# Altium schematic sheet Heater Heat control.SchDoc
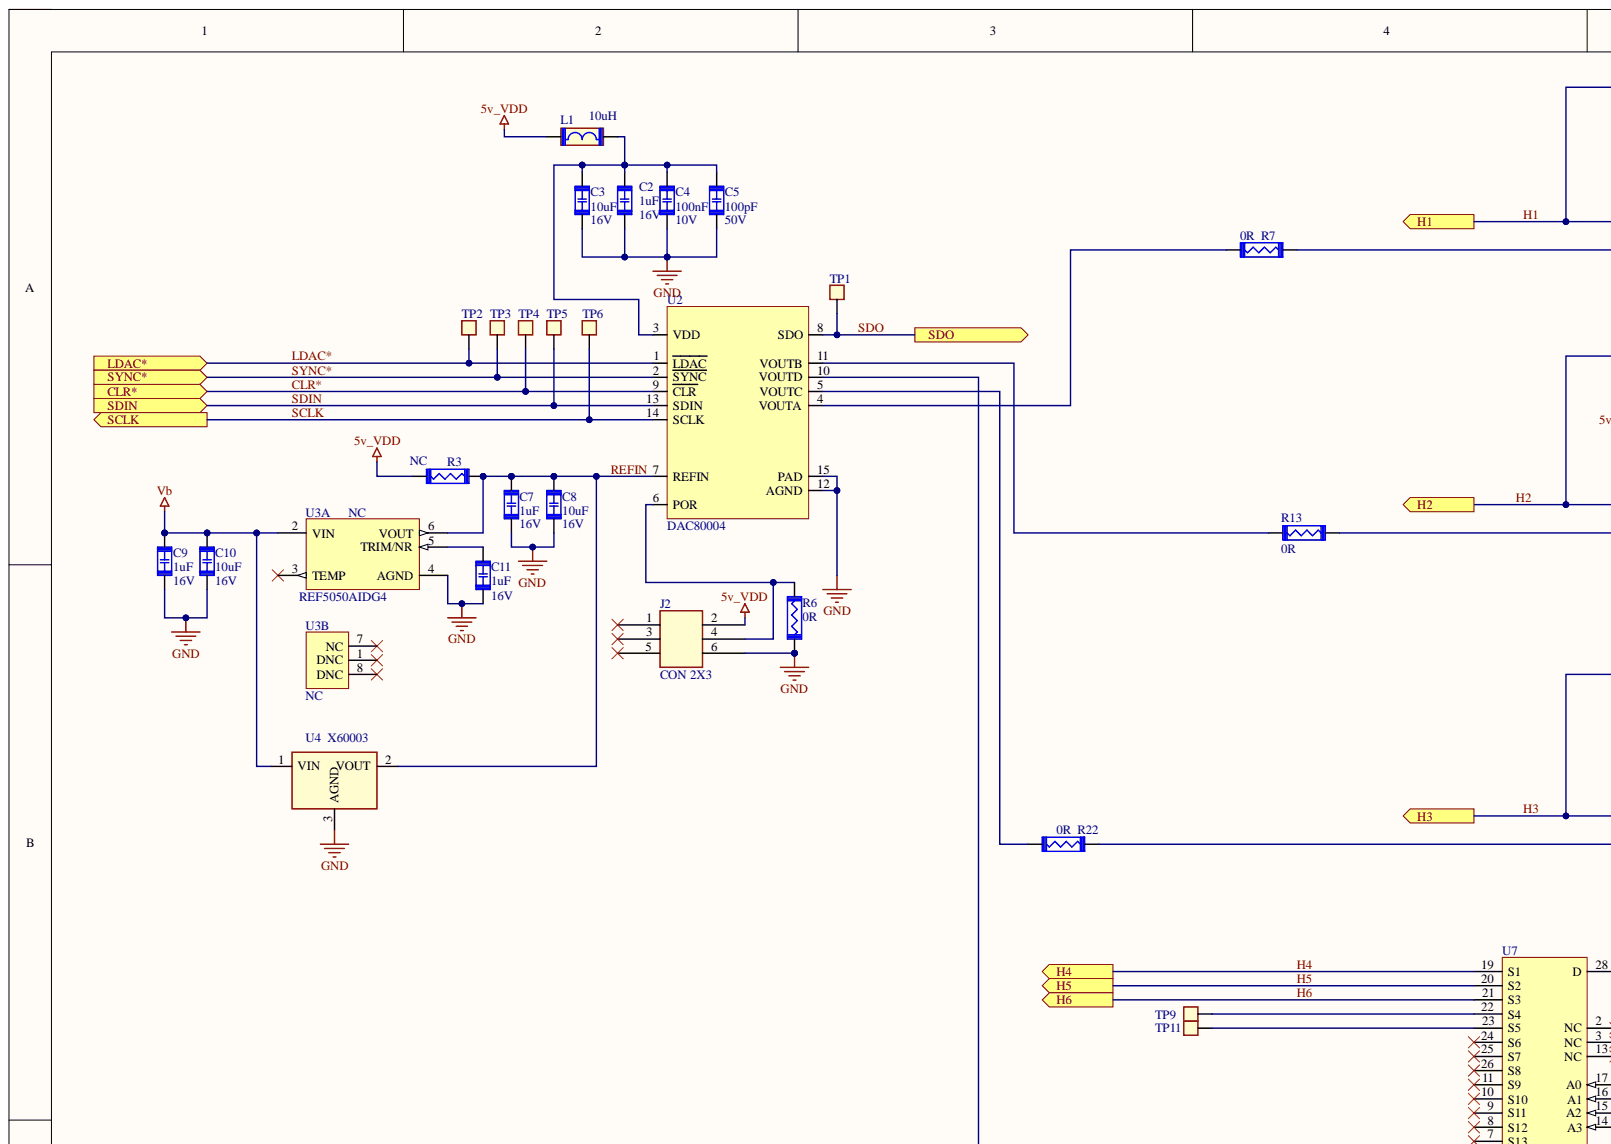
[diagram p0: Heater Heat control.SchDoc, top left]
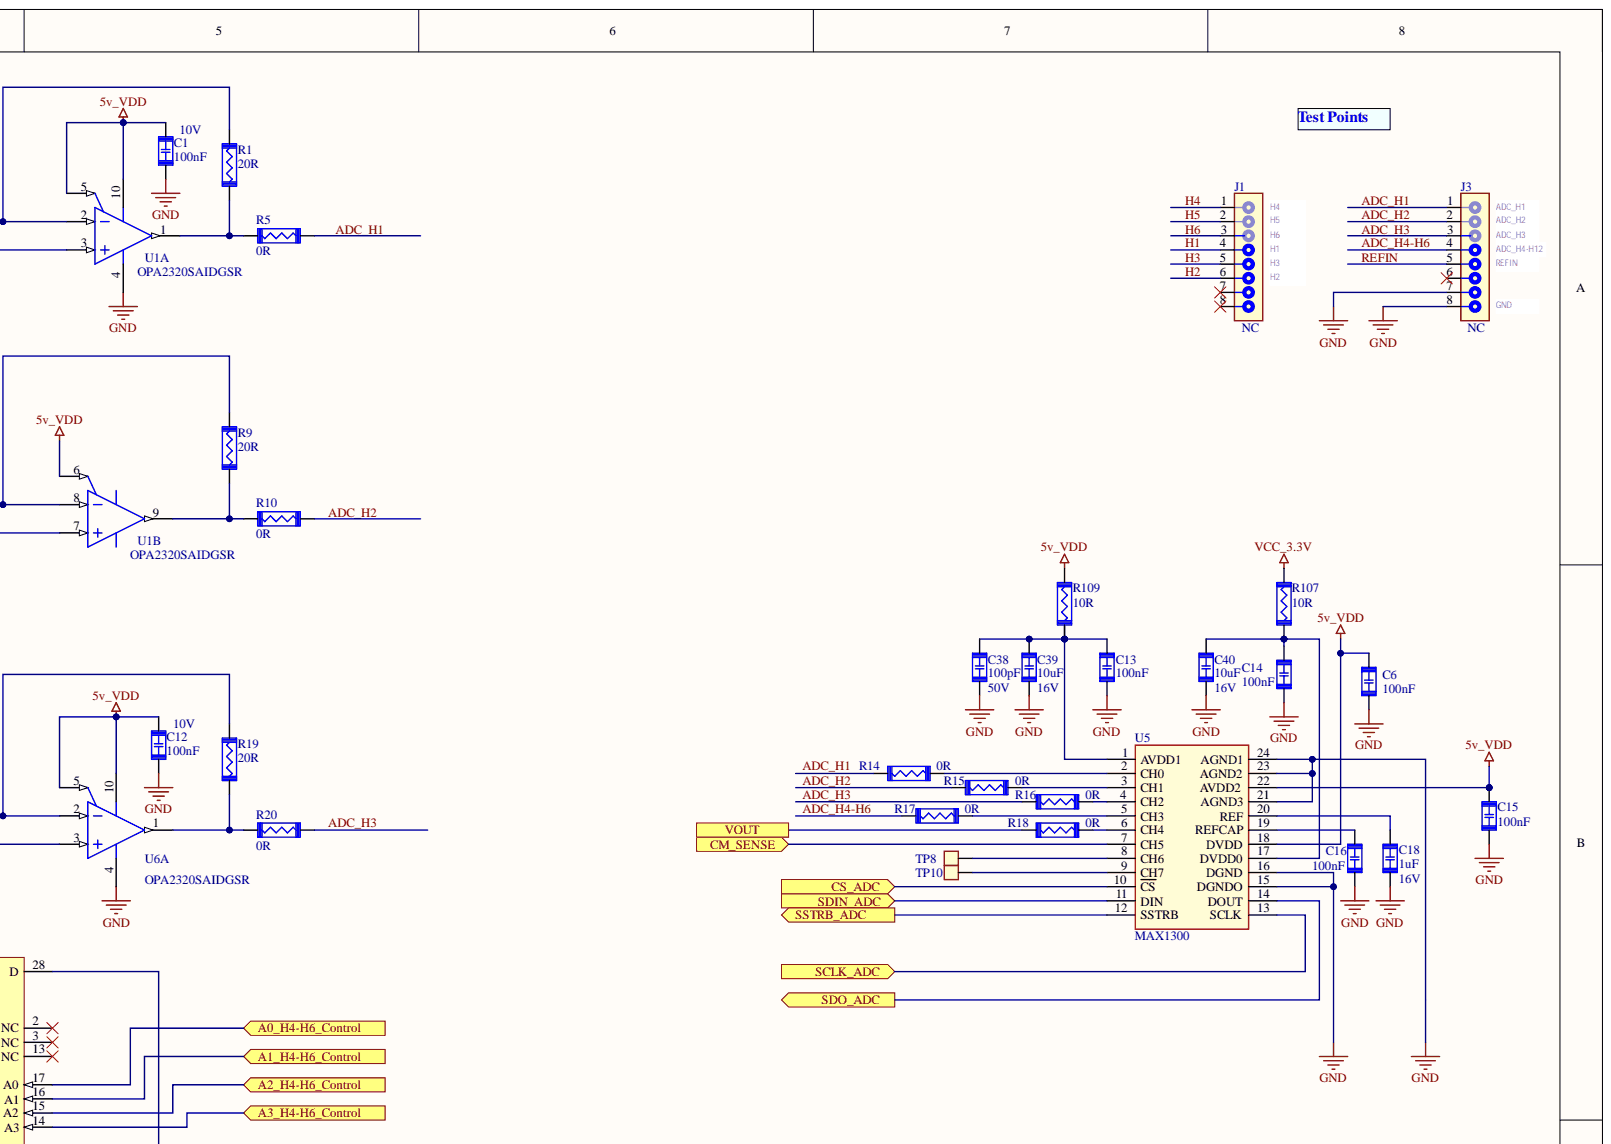
[diagram p1: Heater Heat control.SchDoc, top right]
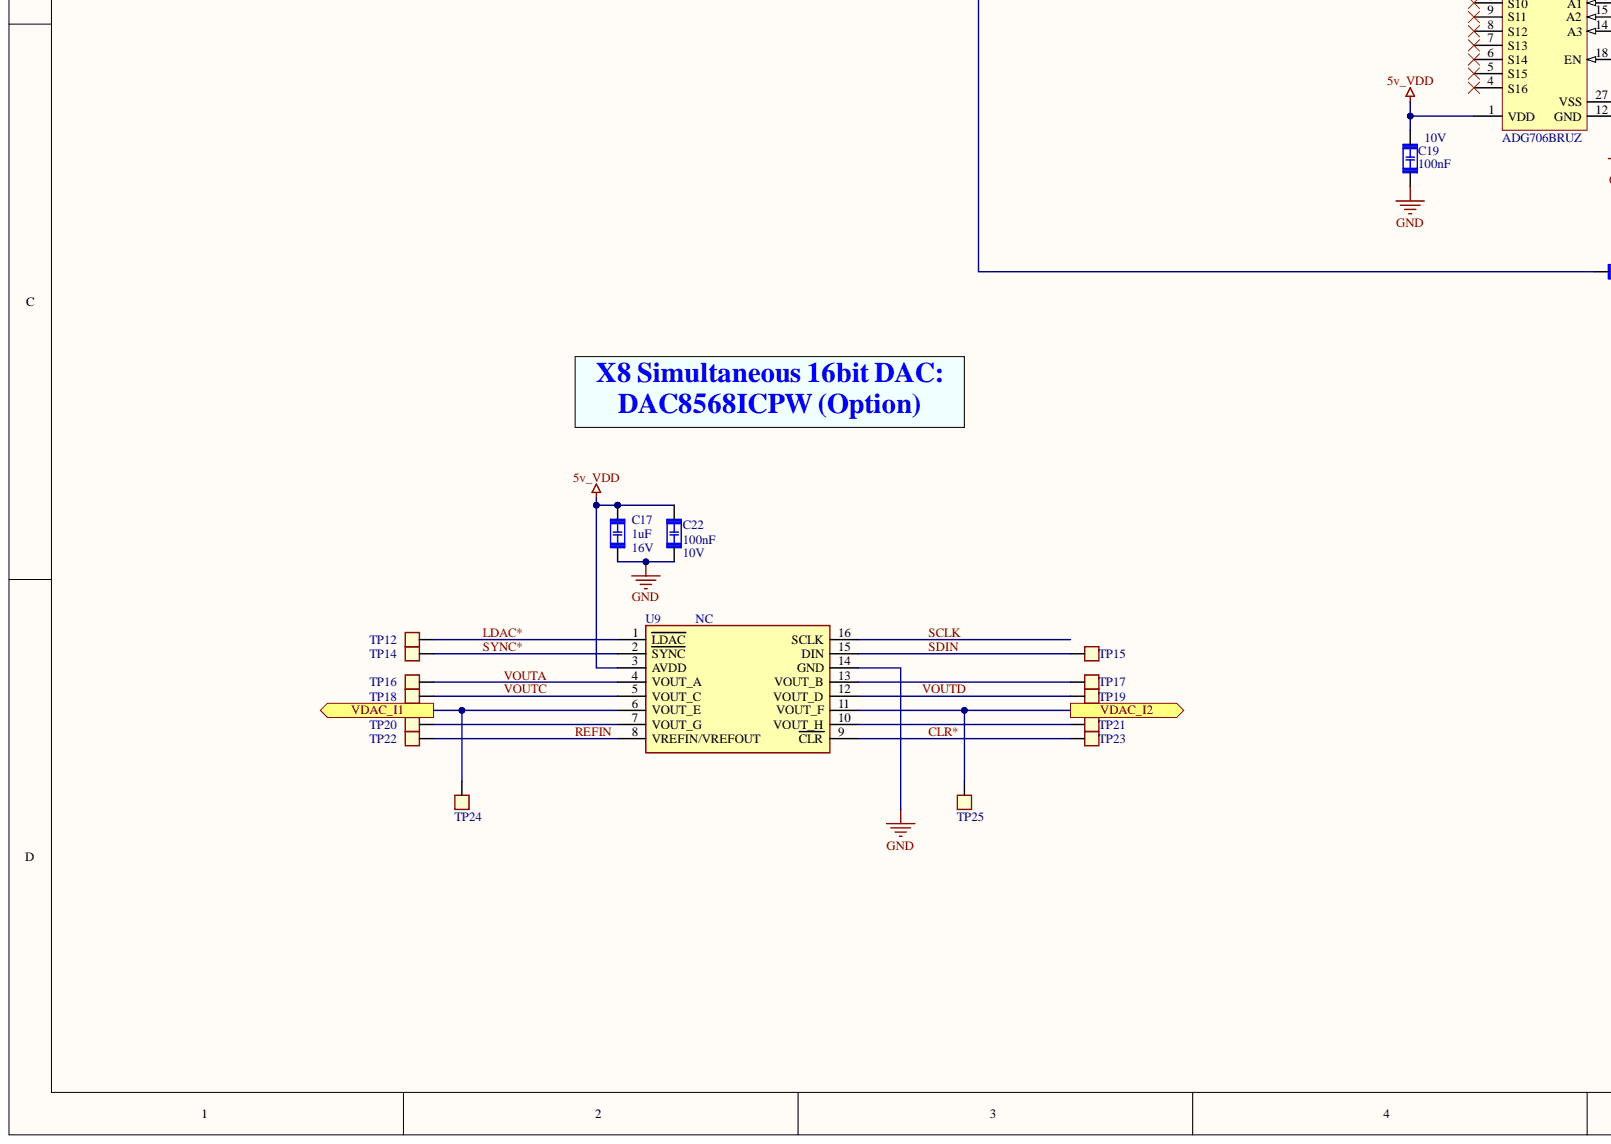
[diagram p2: Heater Heat control.SchDoc, bottom left]
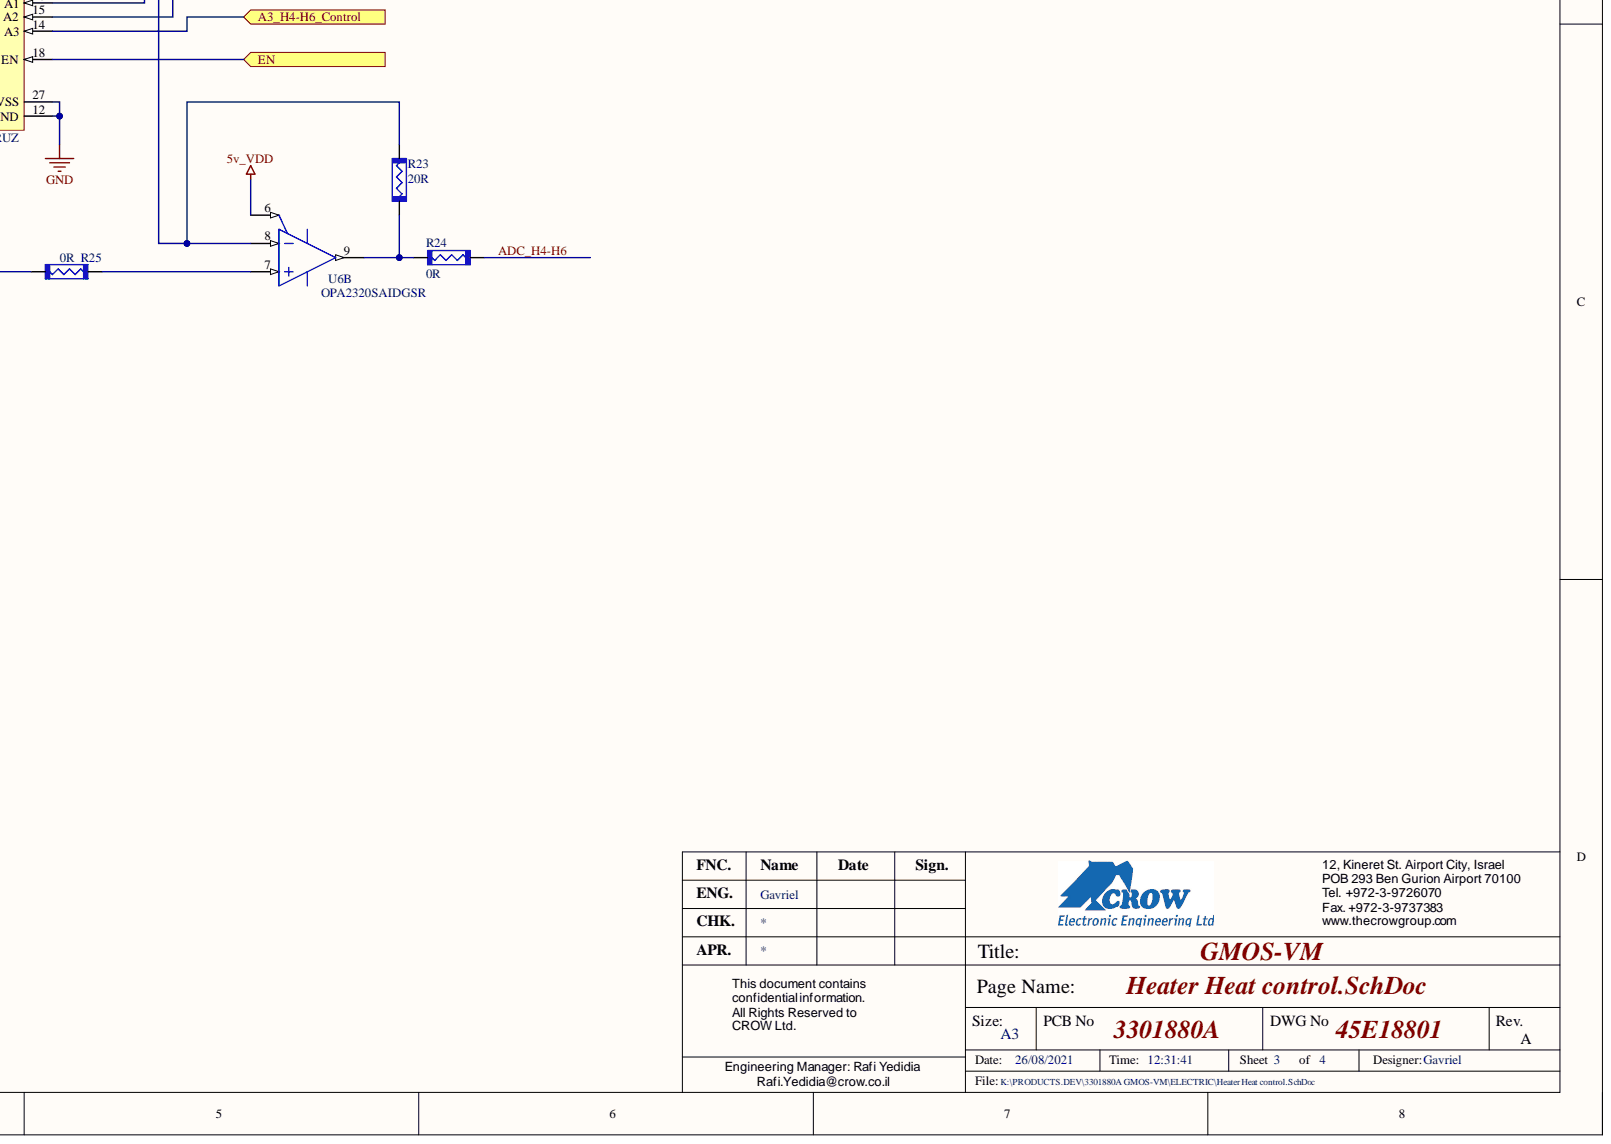
[diagram p3: Heater Heat control.SchDoc, bottom right]

## Parts
C1  100nF  Voltage: 10V  [C0402/C]
C2  1uF  Voltage: 16V  [C0402/C]
C3  10uF  Voltage: 16V  [C0603/C]
C4  100nF  Voltage: 10V  [C0402/C]
C5  100pF  Voltage: 50V  [C0402/C]
C6  100nF  [C0402/C]
C7  1uF  Voltage: 16V  [C0402/C]
C8  10uF  Voltage: 16V  [C0603/C]
C9  1uF  Voltage: 16V  [C0402/C]
C10  10uF  Voltage: 16V  [C0603/C]
C11  1uF  Voltage: 16V  [C0402/C]
C12  100nF  Voltage: 10V  [C0402/C]
C13  100nF  [C0402/C]
C14  100nF  [C0402/C]
C15  100nF  [C0402/C]
C16  100nF  [C0402/C]
C17  1uF  Voltage: 16V  [C0402/C]
C18  1uF  Voltage: 16V  [C0402/C]
C19  100nF  Voltage: 10V  [C0402/C]
C22  100nF  Voltage: 10V  [C0402/C]
C38  100pF  Voltage: 50V  [C0402/C]
C39  10uF  Voltage: 16V  [C0603/C]
C40  10uF  Voltage: 16V  [C0603/C]
J1  NC  [SIP100_8P_3B_H36.5]
J2  CON 2X3  [PH2X3SMT2.54_H2.5]
J3  NC  [SIP100_8P_3B_H36.5]
L1  10uH  [L_epl2010]
R1  20R  [R0402/C]
R3  NC  [R0402/C]
R5  0R  [R0402/C]
R6  0R  [R0402/C]
R7  0R  [R0402/C]
R9  value 20R  [R0402/C]  (hidden comment: Value)
R10  value 0R  [R0402/C]  (hidden comment: Value)
R13  value 0R  [R0402/C]  (hidden comment: Value)
R14  0R  [R0402/C]
R15  0R  [R0402/C]
R16  0R  [R0402/C]
R17  0R  [R0402/C]
R18  0R  [R0402/C]
R19  20R  [R0402/C]
R20  0R  [R0402/C]
R22  0R  [R0402/C]
R23  20R  [R0402/C]
R24  0R  [R0402/C]
R25  0R  [R0402/C]
R107  10R  [R0805/C]
R109  10R  [R0805/C]
TP1  TP-1.5MM  [TP-1.5mm]  (value not drawn)  (no BOM)
TP2  TP-1.5MM  [TP-1.5mm]  (value not drawn)  (no BOM)
TP3  TP-1.5MM  [TP-1.5mm]  (value not drawn)  (no BOM)
TP4  TP-1.5MM  [TP-1.5mm]  (value not drawn)  (no BOM)
TP5  TP-1.5MM  [TP-1.5mm]  (value not drawn)  (no BOM)
TP6  TP-1.5MM  [TP-1.5mm]  (value not drawn)  (no BOM)
TP8  TP-1MM_1  [TP-1.5MM]  (value not drawn)  (no BOM)
TP9  TP-1MM_1  [TP-1.5MM]  (value not drawn)  (no BOM)
TP10  TP-1MM_1  [TP-1.5MM]  (value not drawn)  (no BOM)
TP11  TP-1MM_1  [TP-1.5MM]  (value not drawn)  (no BOM)
TP12  TP-1MM_1  [TP-1.5MM]  (value not drawn)  (no BOM)
TP14  TP-1MM_1  [TP-1.5MM]  (value not drawn)  (no BOM)
TP15  TP-1MM_1  [TP-1.5MM]  (value not drawn)  (no BOM)
TP16  TP-1MM_1  [TP-1.5MM]  (value not drawn)  (no BOM)
TP17  TP-1MM_1  [TP-1.5MM]  (value not drawn)  (no BOM)
TP18  TP-1MM_1  [TP-1.5MM]  (value not drawn)  (no BOM)
TP19  TP-1MM_1  [TP-1.5MM]  (value not drawn)  (no BOM)
TP20  TP-1MM_1  [TP-1.5MM]  (value not drawn)  (no BOM)
TP21  TP-1MM_1  [TP-1.5MM]  (value not drawn)  (no BOM)
TP22  TP-1MM_1  [TP-1.5MM]  (value not drawn)  (no BOM)
TP23  TP-1MM_1  [TP-1.5MM]  (value not drawn)  (no BOM)
TP24  TP-1MM_1  [TP-1.5MM]  (value not drawn)  (no BOM)
TP25  TP-1MM_1  [TP-1.5MM]  (value not drawn)  (no BOM)
U1  OPA2320SAIDGSR  [SOPM_10/C]  (parts A,B)
U2  DAC80004  [VSON14_3x4x1_050]
U3  NC  value REF5050AIDG4  [SOIC8_W6XL5XH1.75]  (parts A,B)
U4  X60003  [SOT23/C]
U5  MAX1300  [TSSOP24_7.8x4.4X1.1_065]
U6  OPA2320SAIDGSR  [SOPM_10/C]  (parts A,B)
U7  ADG706BRUZ  [ADI-RU-28_L]
U9  NC  [TSSOP16_4.4X5.0_0.65]

## Ports
A0_H4-H6_Control, A1_H4-H6_Control, A2_H4-H6_Control, A3_H4-H6_Control, CLR*, CM_SENSE, CS_ADC, EN, H1, H2, H3, H4, H5, H6, LDAC*, SCLK, SCLK_ADC, SDIN, SDIN_ADC, SDO, SDO_ADC, SSTRB_ADC, SYNC*, VDAC_I1, VDAC_I2, VOUT

## Nets
5v_VDD: C1.1 C6.1 C12.1 C15.1 C17.1 C19.1 C22.1 J2.2 L1.1 R3.2 R109.2 U1.5 U1.6 U1.10 U5.18(DVDD) U5.22(AVDD2) U6.5 U6.6 U6.10 U7.1(VDD) U9.3(AVDD)
GND: C1.2 C2.2 C3.2 C4.2 C5.2 C6.2 C7.2 C8.2 C9.2 C10.2 C11.2 C12.2 C13.2 C14.2 C15.2 C16.2 C17.2 C18.2 C19.2 C22.2 C38.1 C39.2 C40.2 J2.6 J3.7 J3.8 R6.2 U1.4 U2.12(AGND) U2.15(PAD) U3.4(AGND) U4.3 U5.15(DGNDO) U5.16(DGND) U5.21(AGND3) U5.23(AGND2) U5.24(AGND1) U6.4 U7.12(GND) U7.27(VSS) U9.14(GND)
Vb: C9.1 C10.1 U3.2(VIN) U4.1
VCC_3.3V: R107.2
A0_H4-H6_Control: U7.17(A0) ; port A0_H4-H6_Control
A1_H4-H6_Control: U7.16(A1) ; port A1_H4-H6_Control
A2_H4-H6_Control: U7.15(A2) ; port A2_H4-H6_Control
A3_H4-H6_Control: U7.14(A3) ; port A3_H4-H6_Control
ADC_H1: J3.1 R5.1 R14.2
ADC_H2: J3.2 R10.1 R15.2
ADC_H3: J3.3 R16.2 R20.1
ADC_H4-H6: J3.4 R17.2 R24.1
CLR*: TP4.1 TP23.1 U2.9(CLR) U9.9(CLR) ; port CLR*
CM_SENSE: U5.7(CH5) ; port CM_SENSE
CS_ADC: U5.10(CS) ; port CS_ADC
EN: U7.18(EN) ; port EN
H1: J1.4 R1.1 U1.2 ; port H1
H2: J1.6 R9.1 U1.8 ; port H2
H3: J1.5 R19.1 U6.2 ; port H3
H4: J1.1 U7.19(S1) ; port H4
H5: J1.2 U7.20(S2) ; port H5
H6: J1.3 U7.21(S3) ; port H6
LDAC*: TP2.1 TP12.1 U2.1(LDAC) U9.1(LDAC) ; port LDAC*
REFIN: C7.1 C8.1 J3.5 R3.1 TP22.1 U2.7(REFIN) U3.6(VOUT) U4.2 U9.8(VREFIN/VREFOUT)
SCLK: TP6.1 U2.14(SCLK) U9.16(SCLK) ; port SCLK
SCLK_ADC: U5.13(SCLK) ; port SCLK_ADC
SDIN: TP5.1 TP15.1 U2.13(SDIN) U9.15(DIN) ; port SDIN
SDIN_ADC: U5.11(DIN) ; port SDIN_ADC
SDO: TP1.1 U2.8(SDO) ; port SDO
SDO_ADC: U5.14(DOUT) ; port SDO_ADC
SSTRB_ADC: U5.12(SSTRB) ; port SSTRB_ADC
SYNC*: TP3.1 TP14.1 U2.2(SYNC) U9.2(SYNC) ; port SYNC*
VDAC_I1: TP24.1 U9.6(VOUT_E) ; port VDAC_I1
VDAC_I2: TP25.1 U9.11(VOUT_F) ; port VDAC_I2
VOUT: R18.2 ; port VOUT
VOUTA: TP16.1 U9.4(VOUT_A)
VOUTC: TP18.1 U9.5(VOUT_C)
VOUTD: TP19.1 U9.12(VOUT_D)
NetC2_1: C2.1 C3.1 C4.1 C5.1 L1.2 U2.3(VDD)
NetC11_1: C11.1 U3.5(TRIM/NR)
NetC13_1: C13.1 C38.2 C39.1 R109.1 U5.1(AVDD1)
NetC14_1: C14.1 C40.1 R107.1 U5.17(DVDD0)
NetC16_1: C16.1 U5.19(REFCAP)
NetC18_1: C18.1 U5.20(REF)
NetJ2_4: J2.4 R6.1 U2.6(POR)
NetR1_2: R1.2 R5.2 U1.1
NetR7_1: R7.1 U1.3
NetR7_2: R7.2 U2.4(VOUTA)
NetR9_2: R9.2 R10.2 U1.9
NetR13_1: R13.1 U1.7
NetR13_2: R13.2 U2.11(VOUTB)
NetR14_1: R14.1 U5.2(CH0)
NetR15_1: R15.1 U5.3(CH1)
NetR16_1: R16.1 U5.4(CH2)
NetR17_1: R17.1 U5.5(CH3)
NetR18_1: R18.1 U5.6(CH4)
NetR19_2: R19.2 R20.2 U6.1
NetR22_1: R22.1 U6.3
NetR22_2: R22.2 U2.5(VOUTC)
NetR23_1: R23.1 U6.8 U7.28(D)
NetR23_2: R23.2 R24.2 U6.9
NetR25_1: R25.1 U6.7
NetR25_2: R25.2 U2.10(VOUTD)
NetTP8_1: TP8.1 U5.8(CH6)
NetTP9_1: TP9.1 U7.22(S4)
NetTP10_1: TP10.1 U5.9(CH7)
NetTP11_1: TP11.1 U7.23(S5)
NetTP17_1: TP17.1 U9.13(VOUT_B)
NetTP20_1: TP20.1 U9.7(VOUT_G)
NetTP21_1: TP21.1 U9.10(VOUT_H)

Not connected: J1 7 8; J2 1 3 5; J3 6; U3 1(DNC) 3(TEMP) 7 8(DNC); U7 2(NC) 3(NC) 4(S16) 5(S15) 6(S14) 7(S13) 8(S12) 9(S11) 10(S10) 11(S9) 13(NC) 24(S6) 25(S7) 26(S8)

## Library data of the parts
C1 C4 C6 C12-C16 C19 C22 = 1235104: CAP.SMD 100nF 10V X7R 0402 10%; Name Manufacturer#1: EYANG1; Name Manufacturer#2: KEMET; PN Manufacturer#1: 0402X104K100CT; PN Manufacturer#2: C0402C104K8RACT; Database Table Name: ALTM_Capacitors; Component Kind: Standard; ComponentLink1Description: Datasheet; Cost: 0.0005; Crow Part Number: 1235104; Package: SMD; Signal Integrity: Model Name; Simulation: Model Name; Tolerance: 10%; Value: 100nF; ComponentLink1URL: G:\SPEC\Capacitor\Ceramics\CAP SMD WALSIN.pdf; HelpURL: G:\SPEC\Capacitor\Ceramics\CAP SMD WALSIN.pdf; Library Name: Database_Crow.DbLib
  C1 C12 C19: Stock: 3379.81
  C4 C22: Stock: 3236.71
  C6 C13-C16: Stock: 3379.81; Voltage: 10V
C2 C7 C9 C11 C17 C18 = 1234105: CAP. SMD 1uF/16V X7R/X5R 0402 10%; Name Manufacturer#1: TDK; Name Manufacturer#2: TDK1; PN Manufacturer#1: C1005X5R1C105K0; PN Manufacturer#2: C1005X5R1C105KT; Database Table Name: ALTM_Capacitors; Component Kind: Standard; ComponentLink1Description: Datasheet; Cost: 0.0032; Crow Part Number: 1234105; Package: SMD; Signal Integrity: Model Name; Simulation: Model Name; Tolerance: 10%; Value: 1uF; Library Name: Database_Crow.DbLib
  C2 C7 C9 C11 C17: Stock: 1709.96
  C18: Stock: 1592.84
C3 C8 C10 C39 C40 = 1236106: CAP. SMD 10uF  0603 16V X5R  20%; Name Manufacturer#1: TDK; PN Manufacturer#1: PC1608X5R1C106M; Database Table Name: ALTM_Capacitors; Component Kind: Standard; ComponentLink1Description: Datasheet; Cost: 0.0306; Crow Part Number: 1236106; Package: SMD; Signal Integrity: Model Name; Simulation: Model Name; Stock: 33.18; Tolerance: 20%; Value: 10uF; Library Name: Database_Crow.DbLib
C5 C38 = 1230101: CAP. SMD 100PF COG 0402; Name Manufacturer#1: SAMSUNG; Name Manufacturer#2: SAMSUNG1; PN Manufacturer#1: CL05C101JB5NNNC; PN Manufacturer#2: CL05C101JB5NNND; Database Table Name: ALTM_Capacitors; Tolerance: 5%; Component Kind: Standard; ComponentLink1Description: Datasheet; Cost: 0.0006; Crow Part Number: 1230101; Package: SMD; Signal Integrity: Model Name; Simulation: Model Name; Stock: 1207.14; Value: 100pF; ComponentLink1URL: G:\SPEC\Capacitor\Ceramics\CAP SMD SAMSUNG.pdf; HelpURL: G:\SPEC\Capacitor\Ceramics\CAP SMD SAMSUNG.pdf; Library Name: Database_Crow.DbLib
J1 J3 = CON HEAD 8P: Component Kind: Standard; Library Name: Sch_Crow.SchLib
J2 = 1612203: CONNECTOR SMD DUAL ROW 6 Pin HEADER 2X3 2.54mm; Name Manufacturer#1: AMTEK; PN Manufacturer#1: PH1M25-203GBCPR; Database Table Name: ALTM_Connectors; Component Kind: Standard; ComponentLink1Description: Datasheet; ComponentLink1URL: G:/SPEC/Connectors/AMTEK/5PH1XXAXX-X-3.pdf; Cost: 0.0843; Crow Part Number: 1612203; HelpURL: G:/SPEC/Connectors/AMTEK/5PH1XXAXX-X-3.pdf; Package: SMD; Stock: 421; Value: CON 2X3; Library Name: Database_Crow.DbLib
L1 = 1519106: Power IND. SMD 10uH/0.39A; Name Manufacturer#1: COILCRAFT; Name Manufacturer#2: TDK; PN Manufacturer#1: EPL2010-103MLC; PN Manufacturer#2: VLS2010ET-100M; Current: 1.2A; Database Table Name: ALTM_Inductors and Transformers; Component Kind: Standard; ComponentLink1Description: Datasheet; ComponentLink1URL: ..\..\system\mail\epl2010.pdf; Cost: 0.1161; Crow Part Number: 1519106; HelpURL: ..\..\system\mail\epl2010.pdf; Package: SMD; Stock: 2000; Value: 10uH; Library Name: Database_Crow.DbLib
R1 R9 R19 R23 = 1134200: SMD RESISTOR 20ohm   0402 1%; Name Manufacturer#1: ROHM; Name Manufacturer#2: YAGEO; PN Manufacturer#1: MCR01MTRF20R0; PN Manufacturer#2: RC0402FR-0720RL; Database Table Name: ALTM_Resistors and Potentiometers; Power: 63mW; Component Kind: Standard; ComponentLink1Description: Datasheet; Cost: 0.0005; Crow Part Number: 1134200; Package: SMD; Signal Integrity: Model Name; Simulation: Model Name; Stock: 38.15; Tolerance: 1%; Library Name: Database_Crow.DbLib
  R1 R19 R23: Value: 20R
R3 = 1120001: SMD RESISTOR 0 ohm 0402 5%; Name Manufacturer#1: VIKING; Name Manufacturer#2: PDC; PN Manufacturer#1: CR-02JL7----0R; PN Manufacturer#2: FCF02JV000; Database Table Name: ALTM_Resistors and Potentiometers; Power: 63mW; Value: 0R; Component Kind: Standard; ComponentLink1Description: Datasheet; Cost: 0.0005; Crow Part Number: 1120001; Package: SMD; Signal Integrity: Model Name; Simulation: Model Name; Stock: 1404.73; Tolerance: 5%; Library Name: Database_Crow.DbLib
R5-R7 R10 R13-R18 R20 R22 R24 R25 = 1120001: SMD RESISTOR 0 ohm 0402 5%; Name Manufacturer#1: VIKING; Name Manufacturer#2: PDC; PN Manufacturer#1: CR-02JL7----0R; PN Manufacturer#2: FCF02JV000; Database Table Name: ALTM_Resistors and Potentiometers; Power: 63mW; Component Kind: Standard; ComponentLink1Description: Datasheet; Cost: 0.0005; Crow Part Number: 1120001; Package: SMD; Signal Integrity: Model Name; Simulation: Model Name; Stock: 1404.73; Tolerance: 5%; Library Name: Database_Crow.DbLib
  R5-R7 R14-R18 R20 R22 R24 R25: Value: 0R
R107 R109 = 1122100: RESISTOR SMD   10 OHM 0805 5%; Name Manufacturer#1: EVER OHMS1; Name Manufacturer#2: ROYAL OHM; PN Manufacturer#1: 0805 5% 10R; PN Manufacturer#2: 0805S8J0100T5E; Database Table Name: ALTM_Resistors and Potentiometers; Power: 100mW; Component Kind: Standard; ComponentLink1Description: Datasheet; Cost: 0.004; Crow Part Number: 1122100; Package: SMD; Signal Integrity: Model Name; Simulation: Model Name; Stock: 65.53; Tolerance: 5%; Value: 10R; Library Name: Database_Crow.DbLib
U1 U6 = 1359320: OP AMP Low-Noise with Shutdown OPA2320SAIDGSR; Name Manufacturer#1: TI; Database Table Name: ALTM_IC; Component Kind: Standard; ComponentLink1Description: Datasheet; ComponentLink1URL: G:/SPEC/IC TI/OPA2320.pdf; Cost: 0.7881; Crow Part Number: 1359320; HelpURL: G:/SPEC/IC TI/OPA2320.pdf; Package: SMD; PN Manufacturer#1: OPA2320SAIDGSR; Stock: 5.12; Value: OPA2320SAIDGSR; Library Name: Database_Crow.DbLib
U2 = DAC80004_TSSOP14: Component Kind: Standard; Value: DAC80004; Library Name: Sch_Crow.SchLib
U3 = REF5050AIDG4: Low Noise, Very Low Drift, Precision Voltage Reference, -40 to 125 degC, 8-pin SOIC (D), Green (RoHS & no Sb/Br); Component Kind: Standard; Library Name: Sch_Crow.SchLib
U4 = X60003_SOT23: X60003 precision 5v voltage reference; Component Kind: Standard; Value: X60003; Library Name: Sch_Crow.SchLib
U5 = MAX1300_TSSOP24: Component Kind: Standard; Value: MAX1300; Library Name: Sch_Crow.SchLib
U7 = CMP-0798-00225-1: 1.8 to 5.5 V / 2.5 V, CMOS Low-Voltage 2.5 Ohm, 16-Channel Multiplexer, -40 to 85 degC, 28-pin SOP (RU-28), Tube; Manufacturer: Analog Devices; Bandwidth (Hz): 25M; Max Analog Signal Range: Vss to Vdd; Ron (ohms): 2.5; Supply V Guar Performance: Dual_(+/-2.5V), Single_(+3V), Single_(+5V); t transition (s): 40n; Code_JEDEC: MO-153-AE; Component Kind: Standard; ComponentLink1Description: Manufacturer URL; ComponentLink1URL: http://www.analog.com/en/index.html; ComponentLink2Description: Datasheet; ComponentLink2URL: http://www.analog.com/static/imported-files/data_sheets/ADG706_707.pdf; ComponentLink3Description: Package Specification; ComponentLink3URL: http://www.analog.com/static/imported-files/packages/249854663RU_28.pdf; DatasheetVersion: Rev. A; Library Name: Altium Content Vault; Mounting Technology: Surface Mount; PackageDescription: 28-Pin Thin Shrink Small Outline Package, Body 9.7 x 4.4 mm, Pitch 0.65 mm; PackageReference: RU-28; PackageVersion: Mar-05; Packing: Tube; PartNumber: ADG706BRUZ
U9 = DAC8568ICPW_TSSOP16: Integrated Circuit; Manufacturer_Name: Texas Instruments; Arrow Part Number: DAC8568ICPW; Arrow Price/Stock: https://www.arrow.com/en/products/dac8568icpw/texas-instruments; Component Kind: Standard; Datasheet Link: http://www.ti.com/lit/ds/symlink/dac8568.pdf; Height: 1.2mm; Manufacturer_Part_Number: DAC8568ICPW; Mouser Part Number: 595-DAC8568ICPW; Mouser Price/Stock: https://www.mouser.com/Search/Refine.aspx?Keyword=595-DAC8568ICPW; Value: DAC8568ICPW; Library Name: Sch_Crow.SchLib
TP1-TP6 = TP-1.5MM: Library Name: Database_Crow.DbLib
TP8-TP12 TP14-TP25 = TP-1MM_1: Library Name: Sch_Crow.SchLib
